# Altium schematic sheet InclinometerMain.SchDoc
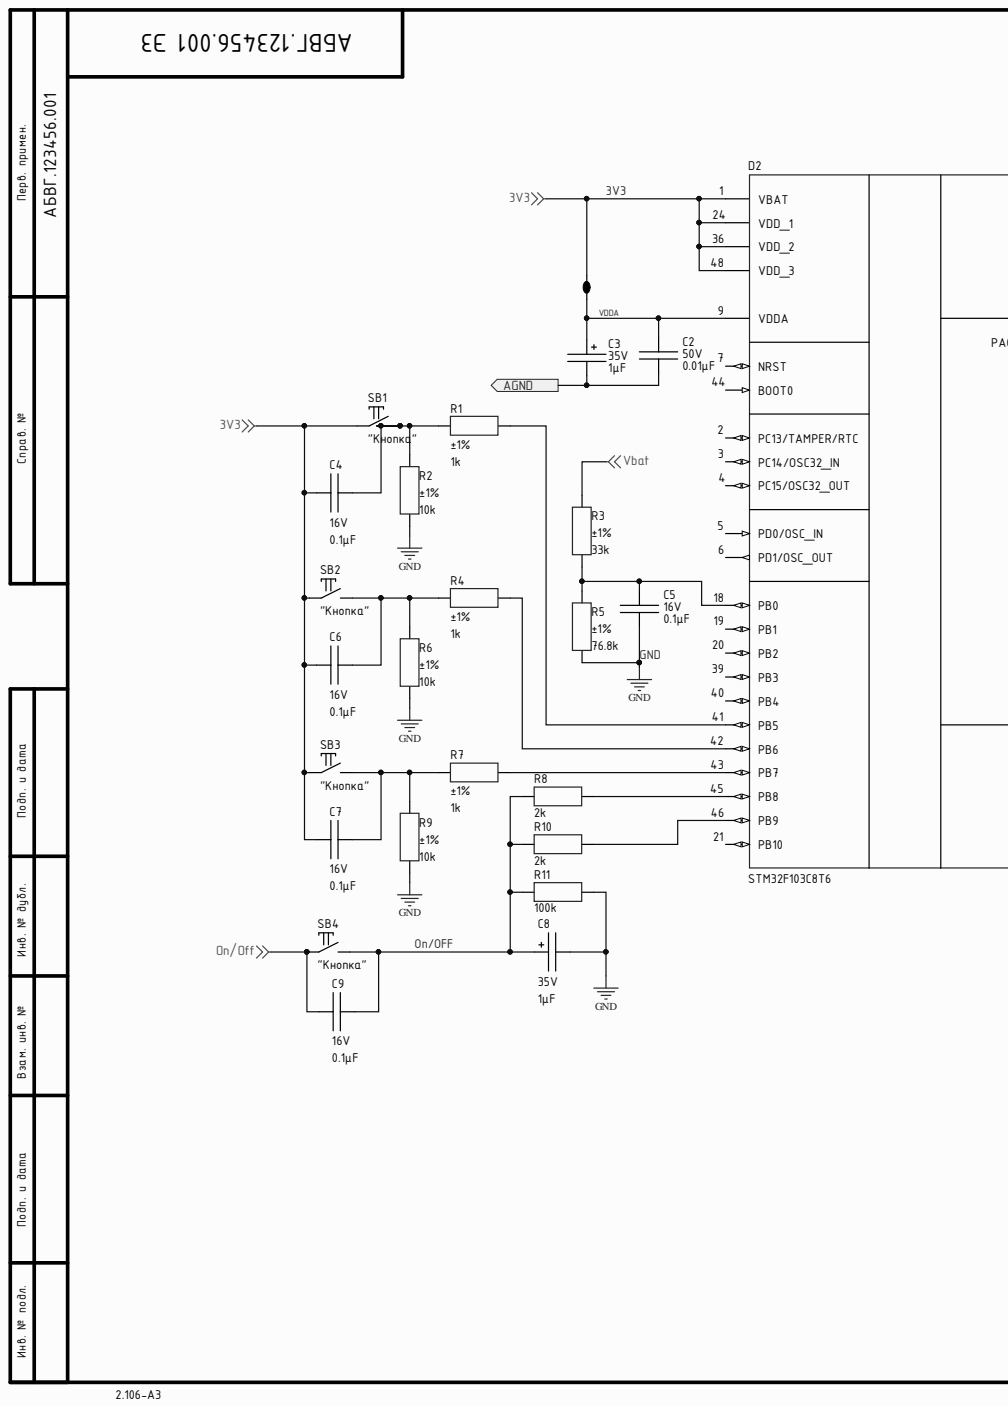
[diagram p0: InclinometerMain.SchDoc, left]
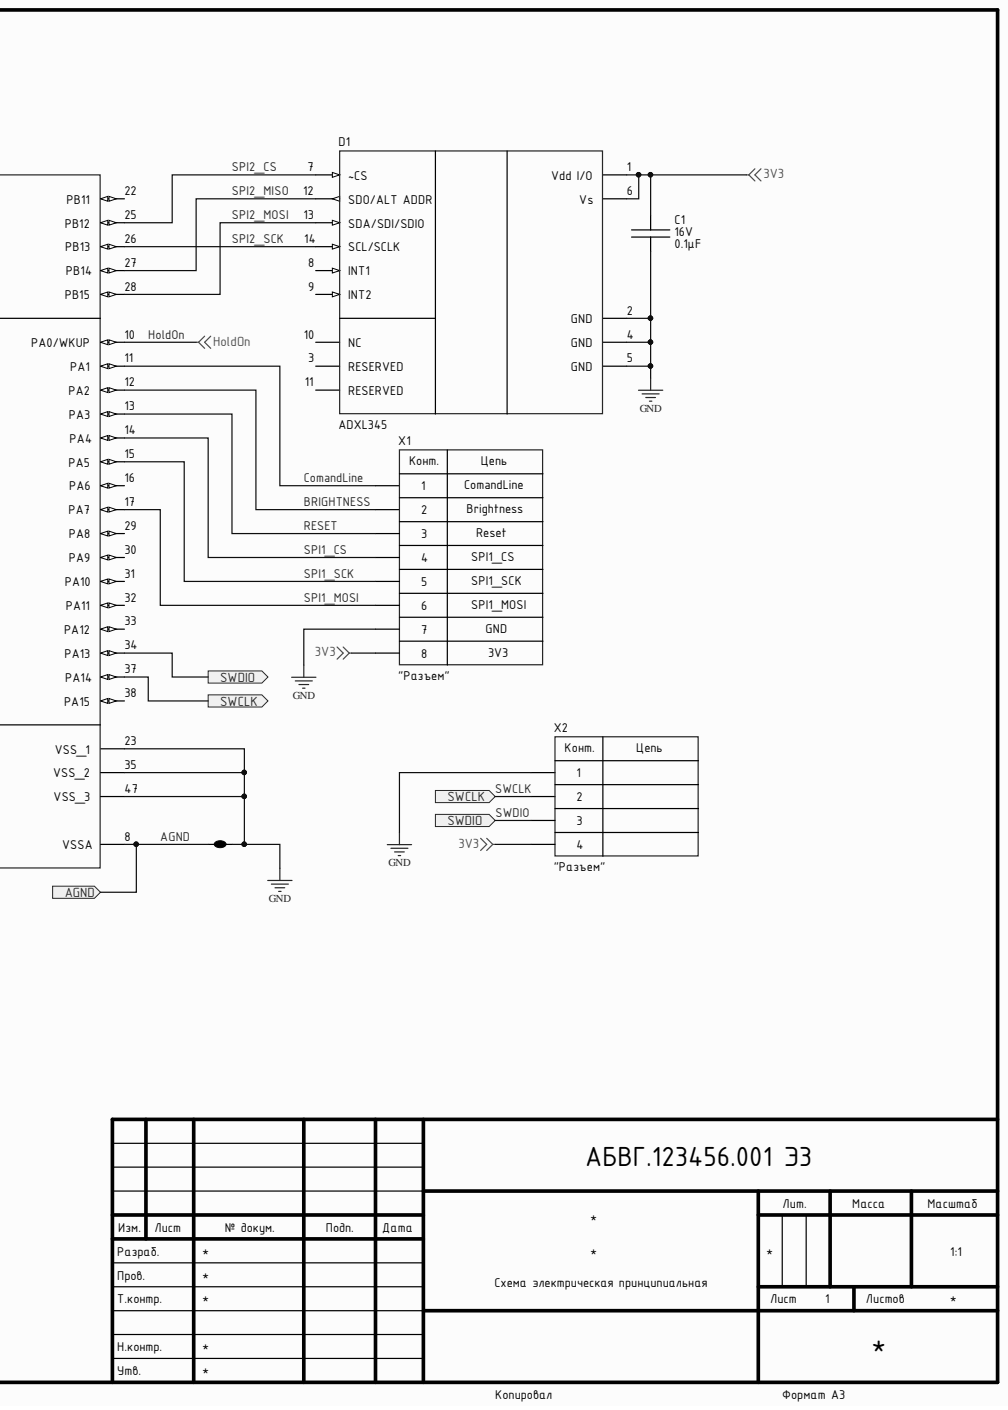
[diagram p1: InclinometerMain.SchDoc, right]

## Parts
C1  value 0.1μF  Voltage: 16V  [CAP: 0603]  (hidden comment: Ceramic capacitor, 0.1μF, X7R, ±10%, 16V, 0603, -55...+125°C)
C2  value 0.01μF  Voltage: 50V  [CAP: 0603]  (hidden comment: Ceramic capacitor, 0.01μF, X7R, ±10%, 50V, 0603, -55...+125°C)
C3  value 1μF  Voltage: 35V  [CAP: TANTAL-A]  (hidden comment: Tantalum capacitor, 1μF, 35V, ±10%, 7.5Ohm, case A (3216), -55...+125°C)
C4  value 0.1μF  Voltage: 16V  [CAP: 0603]  (hidden comment: Ceramic capacitor, 0.1μF, X7R, ±10%, 16V, 0603, -55...+125°C)
C5  value 0.1μF  Voltage: 16V  [CAP: 0603]  (hidden comment: Ceramic capacitor, 0.1μF, X7R, ±10%, 16V, 0603, -55...+125°C)
C6  value 0.1μF  Voltage: 16V  [CAP: 0603]  (hidden comment: Ceramic capacitor, 0.1μF, X7R, ±10%, 16V, 0603, -55...+125°C)
C7  value 0.1μF  Voltage: 16V  [CAP: 0603]  (hidden comment: Ceramic capacitor, 0.1μF, X7R, ±10%, 16V, 0603, -55...+125°C)
C8  value 1μF  Voltage: 35V  [CAP: TANTAL-A]  (hidden comment: Tantalum capacitor, 1μF, 35V, ±10%, 7.5Ohm, case A (3216), -55...+125°C)
C9  value 0.1μF  Voltage: 16V  [CAP: 0603]  (hidden comment: Ceramic capacitor, 0.1μF, X7R, ±10%, 16V, 0603, -55...+125°C)
D1  ADXL345  [ADXL345]
D2  STM32F103C8T6  [LQFP48]
J1  2 way net tie junction  [2way_MP]  (value not drawn)
J2  2 way net tie junction  [2way_MP]  (value not drawn)
R1  value 1k  Tolerance: ±1%  [RES: 0603]  (hidden comment: Resistor film, 1k, ±1%, 0603, 0.1W, -55...+155°C)
R2  value 10k  Tolerance: ±1%  [RES: 0603]  (hidden comment: Resistor film, 10k, ±1%, 0603, 0.1W, -55...+155°C)
R3  value 33k  Tolerance: ±1%  [RES: 0603]  (hidden comment: Resistor film, 33k, ±1%, 0603, 0.1W, -55...+155°C)
R4  value 1k  Tolerance: ±1%  [RES: 0603]  (hidden comment: Resistor film, 1k, ±1%, 0603, 0.1W, -55...+155°C)
R5  value 76.8k  Tolerance: ±1%  [RES: 0603]  (hidden comment: Resistor film, 76.8k, ±1%, 0603, 0.1W, -55...+155°C)
R6  value 10k  Tolerance: ±1%  [RES: 0603]  (hidden comment: Resistor film, 10k, ±1%, 0603, 0.1W, -55...+155°C)
R7  value 1k  Tolerance: ±1%  [RES: 0603]  (hidden comment: Resistor film, 1k, ±1%, 0603, 0.1W, -55...+155°C)
R8  value 2k  [RES: 0603]  (hidden comment: Resistor film, 2k, ±1%, 0603, 0.1W, -55...+155°C)
R9  value 10k  Tolerance: ±1%  [RES: 0603]  (hidden comment: Resistor film, 10k, ±1%, 0603, 0.1W, -55...+155°C)
R10  value 2k  [RES: 0603]  (hidden comment: Resistor film, 2k, ±1%, 0603, 0.1W, -55...+155°C)
R11  value 100k  [RES: 0603]  (hidden comment: Resistor film, 100k, ±5%, 0603, 0.1W, -55...+155°C)
SB1  CommentText: "Кнопка"  [BUT: 6x6]  (hidden comment: Tactile button, 6x6mm)
SB2  CommentText: "Кнопка"  [BUT: 6x6]  (hidden comment: Tactile button, 6x6mm)
SB3  CommentText: "Кнопка"  [BUT: 6x6]  (hidden comment: Tactile button, 6x6mm)
SB4  CommentText: "Кнопка"  [BUT: 6x6]  (hidden comment: Tactile button, 6x6mm)
X1  CommentText: "Разъем"  [CON: PBS-8]  (hidden comment: Connector, 8pin, 2.54mm, 500VAC, 3A)
X2  CommentText: "Разъем"  [CON: PLS-4]  (hidden comment: Connector, 4pin, 2.54mm, 500VAC, 3A)

## Ports
AGND, SWCLK, SWDIO

## Nets
Vbat: R3.2
3V3: C1.2 C4.1 C6.1 C7.1 D1.1(I/O) D1.6(Vs) D2.1(VBAT) D2.24(VDD_1) D2.36(VDD_2) D2.48(VDD_3) J1.1 SB1.1 SB2.1 SB3.1 X1.8 X2.4
AGND: C2.1 C3.2 D2.8(VSSA) J2.1 ; port AGND
BRIGHTNESS: D2.12(PA2) X1.2
ComandLine: D2.11(PA1) X1.1
GND: C1.1 C5.1 C8.2 D1.2(GND) D1.4(GND) D1.5(GND) D2.23(VSS_1) D2.35(VSS_2) D2.47(VSS_3) J2.2 R2.1 R5.1 R6.1 R9.1 R11.2 X1.7 X2.1
HoldOn: D2.10(PA0/WKUP)
On/OFF: C8.1 C9.1 C9.2 R8.1 R10.1 R11.1 SB4.1 SB4.2
RESET: D2.13(PA3) X1.3
SPI1_CS: D2.14(PA4) X1.4
SPI1_MOSI: D2.17(PA7) X1.6
SPI1_SCK: D2.15(PA5) X1.5
SPI2_CS: D1.7(~CS) D2.25(PB12)
SPI2_MISO: D1.12(SDO/ALT) D2.27(PB14)
SPI2_MOSI: D1.13(SDA/SDI/SDIO) D2.28(PB15)
SPI2_SCK: D1.14(SCL/SCLK) D2.26(PB13)
SWCLK: D2.37(PA14) X2.2 ; port SWCLK
SWDIO: D2.34(PA13) X2.3 ; port SWDIO
VDDA: C2.2 C3.1 D2.9(VDDA) J1.2
NetC4_2: C4.2 R1.1 R2.2 SB1.2
NetC5_2: C5.2 D2.18(PB0) R3.1 R5.2
NetC6_2: C6.2 R4.1 R6.2 SB2.2
NetC7_2: C7.2 R7.1 R9.2 SB3.2
NetD2_41: D2.41(PB5) R1.2
NetD2_42: D2.42(PB6) R4.2
NetD2_43: D2.43(PB7) R7.2
NetD2_45: D2.45(PB8) R8.2
NetD2_46: D2.46(PB9) R10.2

Not connected: D1 3(RESERVED) 8(INT1) 9(INT2) 10(NC) 11(RESERVED); D2 2(PC13/TAMPER/RTC) 3(PC14/OSC32_IN) 4(PC15/OSC32_OUT) 5(PD0/OSC_IN) 6(PD1/OSC_OUT) 7(NRST) 16(PA6) 19(PB1) 20(PB2) 21(PB10) 22(PB11) 29(PA8) 30(PA9) 31(PA10) 32(PA11) 33(PA12) 38(PA15) 39(PB3) 40(PB4) 44(BOOT0)

## Library data of the parts
C1 C4-C7 C9 = Ceramic_0603: Manufacturer: Murata Electronics; PartNumber: GRM188R71C104KA01D; Component Kind: Standard; HelpURL: https://www.digikey.com/product-detail/en/murata-electronics/GRM188R71C104KA01D/490-1532-2-ND/587060; Library Name: Capacitor.IntLib; Package: 0603; Temperature: -55...+125°C; Tolerance: ±10%; TypeCapacitor: Ceramic capacitor; TypeDielectric: X7R; ValueManufacturerPartNumber: Ceramic capacitor, 0.1μF, X7R, ±10%, 16V, 0603, -55...+125°C
  C4: Value: 0.1μF
C2 = Ceramic_0603: Manufacturer: Murata Electronics; PartNumber: GRM188R71H103KA01D; Component Kind: Standard; HelpURL: https://www.digikey.com/product-detail/en/murata-electronics/GRM188R71H103KA01D/490-1512-2-ND/587151; Library Name: Capacitor.IntLib; Package: 0603; Temperature: -55...+125°C; Tolerance: ±10%; TypeCapacitor: Ceramic capacitor; TypeDielectric: X7R; ValueManufacturerPartNumber: Ceramic capacitor, 0.01μF, X7R, ±10%, 50V, 0603, -55...+125°C
C3 C8 = Tantal_A: Manufacturer: AVX; PartNumber: TAJA105K035RNJ; Component Kind: Standard; HelpURL: https://www.digikey.com/product-detail/en/avx-corporation/TAJA105K035RNJ/478-1651-2-ND/563759; Impedance: 7.5Ohm; Library Name: Capacitor.IntLib; Package: case A (3216); Temperature: -55...+125°C; Tolerance: ±10%; TypeCapacitor: Tantalum capacitor; ValueManufacturerPartNumber: Tantalum capacitor, 1μF, 35V, ±10%, 7.5Ohm, case A (3216), -55...+125°C
D1 = ADXL345: Axlerometer sensor; Manufacture: Analog Device; Case: СС-14-1; Component Kind: Standard; Help URL: https://www.analog.com/media/en/technical-documentation/data-sheets/ADXL345.pdf; Library Name: Sensors.IntLib; Model: ADXL345
D2 = STM32F103C8T6: 32bit microcontroller; Manufacture: ST; Case: LQFP48 (7x7mm); Component Kind: Standard; Library Name: STM32.IntLib; Model: STM32F103C8T6
J1 J2 = 2way: Component Kind: Net Tie; ComponentLink1Description: Source; ComponentLink1URL: http://www.edatools.cz/addons; Description: 2 way net tie junction; FootprintNote: LP=12mil, MP=40mil, HP=120mil; Library Name: NetTieLib.IntLib
R1 R4 R7 = Resistor_0603: Manufacturer: Yageo; PartNumber: RC0603FR-071KL; Component Kind: Standard; HelpURL: https://www.digikey.com/product-detail/en/yageo/RC0603FR-071KL/311-1-00KHRCT-ND/729790; Library Name: Resistor.IntLib; Package: 0603; Power: 0.1W; Temperature: -55...+155°C; TypeResistor: Resistor film; Value: 1k; ValueManufacturerPartNumber: Resistor film, 1k, ±1%, 0603, 0.1W, -55...+155°C
R2 R6 R9 = Resistor_0603: Manufacturer: Yageo; PartNumber: RC0603FR-0710KL; Component Kind: Standard; HelpURL: https://www.digikey.com/product-detail/en/yageo/RC0603FR-0710KL/311-10-0KHRCT-ND/729827; Library Name: Resistor.IntLib; Package: 0603; Power: 0.1W; Temperature: -55...+155°C; TypeResistor: Resistor film; ValueManufacturerPartNumber: Resistor film, 10k, ±1%, 0603, 0.1W, -55...+155°C
R3 = Resistor_0603: Manufacturer: Yageo; PartNumber: RC0603JR-0733KL; Component Kind: Standard; HelpURL: https://www.digikey.com/product-detail/en/yageo/RC0603FR-0720KL/311-20-0KHRCT-ND/729987; Library Name: Resistor.IntLib; Package: 0603; Power: 0.1W; Temperature: -55...+155°C; TypeResistor: Resistor film; ValueManufacturerPartNumber: Resistor film, 33k, ±1%, 0603, 0.1W, -55...+155°C
R5 = Resistor_0603: Manufacturer: Yageo; PartNumber: RC0603FR-0776K8L; Component Kind: Standard; HelpURL: https://www.digikey.com/product-detail/en/yageo/RC0603FR-0710KL/311-10-0KHRCT-ND/729827; Library Name: Resistor.IntLib; Package: 0603; Power: 0.1W; Temperature: -55...+155°C; TypeResistor: Resistor film; ValueManufacturerPartNumber: Resistor film, 76.8k, ±1%, 0603, 0.1W, -55...+155°C
R8 R10 = Resistor_0603: Manufacturer: Yageo; PartNumber: RC0603FR-072KL; Component Kind: Standard; HelpURL: https://www.digikey.com/product-detail/en/yageo/RC0603FR-071KL/311-1-00KHRCT-ND/729790; Library Name: Resistor.IntLib; Package: 0603; Power: 0.1W; Temperature: -55...+155°C; Tolerance: ±1%; TypeResistor: Resistor film; ValueManufacturerPartNumber: Resistor film, 2k, ±1%, 0603, 0.1W, -55...+155°C
R11 = Resistor_0603: Manufacturer: Yageo; PartNumber: RC0603JR-07100KL; Component Kind: Standard; HelpURL: https://www.digikey.com/product-detail/en/yageo/RC0603FR-071KL/311-1-00KHRCT-ND/729790; Library Name: Resistor.IntLib; Package: 0603; Power: 0.1W; Temperature: -55...+155°C; Tolerance: ±5%; TypeResistor: Resistor film; ValueManufacturerPartNumber: Resistor film, 100k, ±5%, 0603, 0.1W, -55...+155°C
SB1-SB4 = Button_tactile: Manufacturer: TE Connectivity; PartNumber: FSM2JSMAATR; Component Kind: Standard; HelpURL: https://www.digikey.com/product-detail/en/te-connectivity-alcoswitch-switches/FSM2JSMAATR/450-1792-1-ND/3503931; Library Name: Electromechanics.IntLib; Package: 6x6mm; TypeButton: Tactile button; ValueManufacturerPartNumber: Tactile button, 6x6mm
X1 = PBS-8: Manufacturer: Connfly; Component Kind: Standard; CurrentMax: 3A; HelpURL: https://www.chipdip.ru/product/pbs-8; Library Name: Connector.IntLib; Multichannel: 8pin; PartNumber: PBS-8; Pitch: 2.54mm; TypeConnector: Connector; ValueManufacturerPartNumber: Connector, 8pin, 2.54mm, 500VAC, 3A; VoltageMax: 500VAC
X2 = PLS-4: Manufacturer: Connfly; Component Kind: Standard; CurrentMax: 3A; HelpURL: https://www.chipdip.ru/product/pls-4; Library Name: Connector.IntLib; Multichannel: 4pin; PartNumber: PLS-4; Pitch: 2.54mm; TypeConnector: Connector; ValueManufacturerPartNumber: Connector, 4pin, 2.54mm, 500VAC, 3A; VoltageMax: 500VAC
